# Altium schematic sheet Temp Sensors.SchDoc("Temp Sensors")
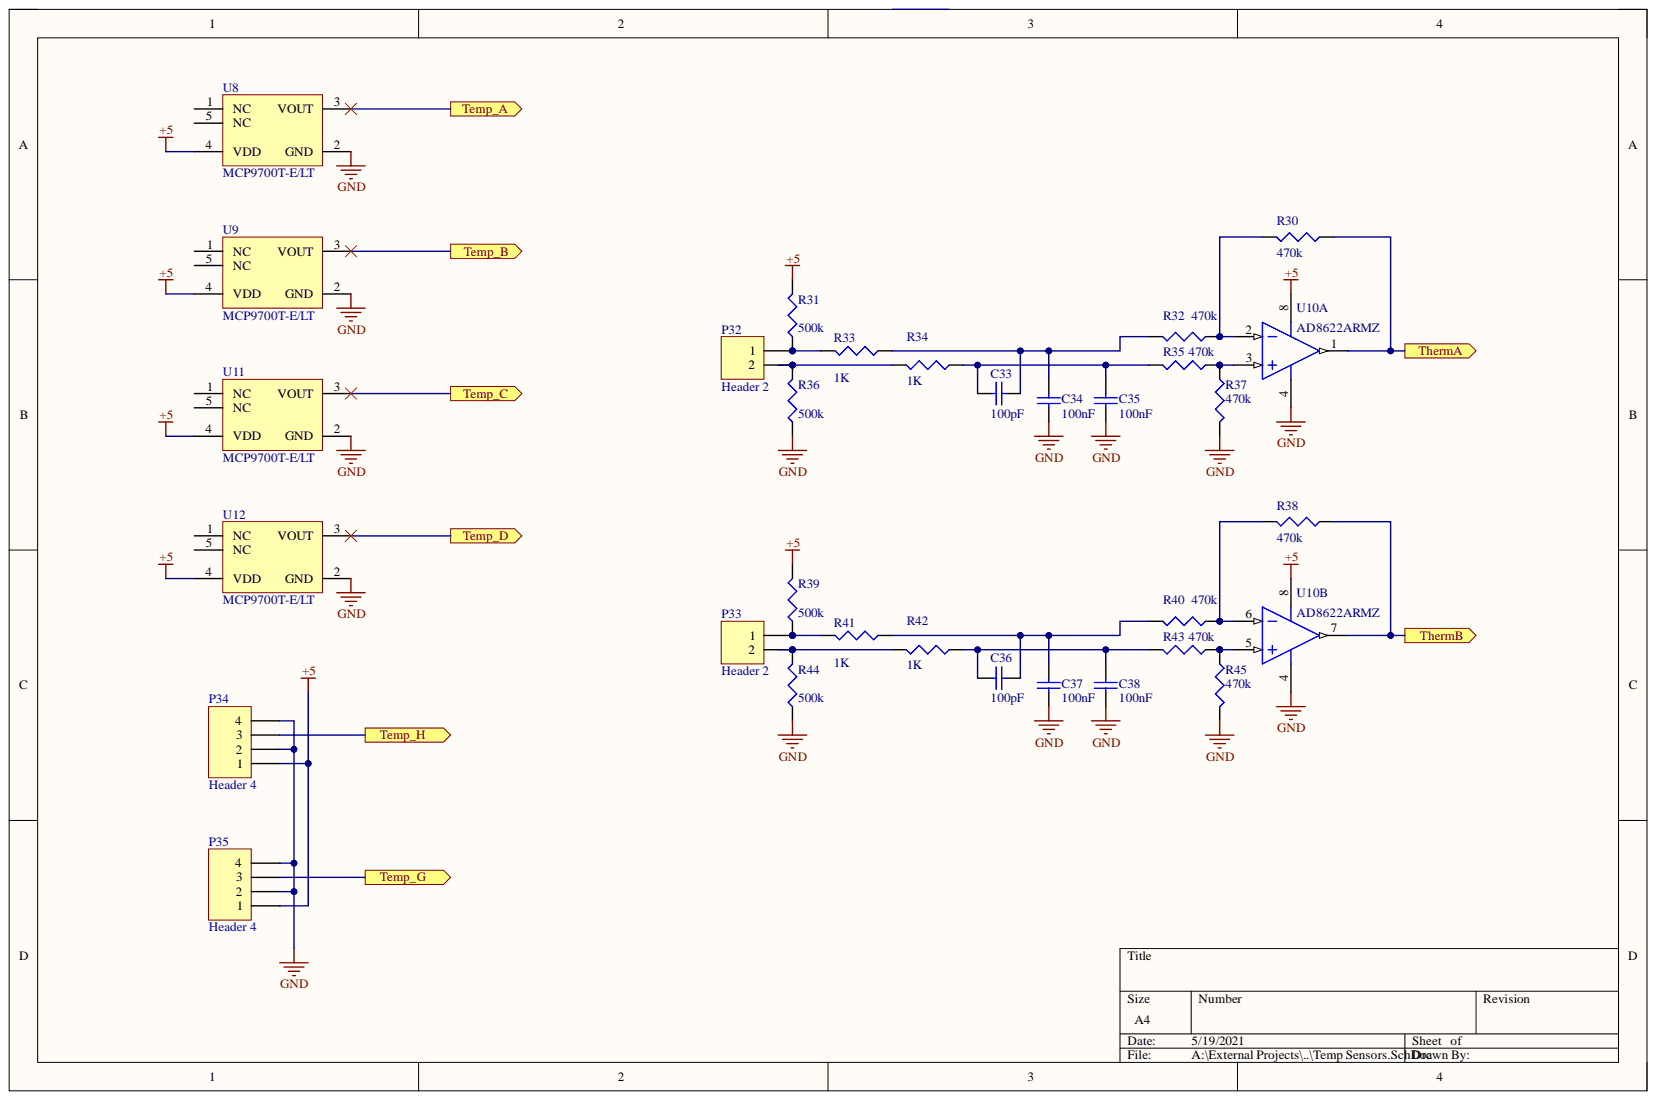
[diagram p0: Temp Sensors.SchDoc]

## Parts
C33  value 100pF  [C1206]  (hidden comment: Cap Semi)
C34  value 100nF  [C1206]  (hidden comment: Cap Semi)
C35  value 100nF  [C1206]  (hidden comment: Cap Semi)
C36  value 100pF  [C1206]  (hidden comment: Cap Semi)
C37  value 100nF  [C1206]  (hidden comment: Cap Semi)
C38  value 100nF  [C1206]  (hidden comment: Cap Semi)
P32  Header 2  [HDR1X2]
P33  Header 2  [HDR1X2]
P34  Header 4  [HDR1X4]
P35  Header 4  [HDR1X4]
R30  value 470k  [J1-0603]  (hidden comment: Res3)
R31  value 500k  [J1-0603]  (hidden comment: Res3)
R32  value 470k  [J1-0603]  (hidden comment: Res3)
R33  value 1K  [J1-0603]  (hidden comment: Res3)
R34  value 1K  [J1-0603]  (hidden comment: Res3)
R35  value 470k  [J1-0603]  (hidden comment: Res3)
R36  value 500k  [J1-0603]  (hidden comment: Res3)
R37  value 470k  [J1-0603]  (hidden comment: Res3)
R38  value 470k  [J1-0603]  (hidden comment: Res3)
R39  value 500k  [J1-0603]  (hidden comment: Res3)
R40  value 470k  [J1-0603]  (hidden comment: Res3)
R41  value 1K  [J1-0603]  (hidden comment: Res3)
R42  value 1K  [J1-0603]  (hidden comment: Res3)
R43  value 470k  [J1-0603]  (hidden comment: Res3)
R44  value 500k  [J1-0603]  (hidden comment: Res3)
R45  value 470k  [J1-0603]  (hidden comment: Res3)
U8  MCP9700T-E/LT  [SC70-LT5_M]
U9  MCP9700T-E/LT  [SC70-LT5_M]
U10  AD8622ARMZ  [ADI-RM-8_L]  (parts A,B)
U11  MCP9700T-E/LT  [SC70-LT5_M]
U12  MCP9700T-E/LT  [SC70-LT5_M]

## Ports
Temp_A, Temp_B, Temp_C, Temp_D, Temp_G, Temp_H, ThermA, ThermB

## Nets
+5: P34.1 P35.1 R31.2 R39.2 U8.4(VDD) U9.4(VDD) U10.8 U11.4(VDD) U12.4(VDD)
GND: C34.1 C35.1 C37.1 C38.1 P34.2 P34.4 P35.2 P35.4 R36.1 R37.2 R44.1 R45.2 U8.2(GND) U9.2(GND) U10.4 U11.2(GND) U12.2(GND)
Temp_A: U8.3(VOUT) ; port Temp_A
Temp_B: U9.3(VOUT) ; port Temp_B
Temp_C: U11.3(VOUT) ; port Temp_C
Temp_D: U12.3(VOUT) ; port Temp_D
Temp_G: P35.3 ; port Temp_G
Temp_H: P34.3 ; port Temp_H
ThermA: R30.1 U10.1 ; port ThermA
ThermB: R38.1 U10.7 ; port ThermB
NetC33_1: C33.1 C35.2 R34.1 R35.2
NetC33_2: C33.2 C34.2 R32.2 R33.1
NetC36_1: C36.1 C38.2 R42.1 R43.2
NetC36_2: C36.2 C37.2 R40.2 R41.1
NetP32_1: P32.1 R31.1 R33.2
NetP32_2: P32.2 R34.2 R36.2
NetP33_1: P33.1 R39.1 R41.2
NetP33_2: P33.2 R42.2 R44.2
NetR30_2: R30.2 R32.1 U10.2
NetR35_1: R35.1 R37.1 U10.3
NetR38_2: R38.2 R40.1 U10.6
NetR43_1: R43.1 R45.1 U10.5

Not connected: U8 1(NC) 5(NC); U9 1(NC) 5(NC); U11 1(NC) 5(NC); U12 1(NC) 5(NC)

## Library data of the parts
C33-C38 = Cap Semi: Capacitor (Semiconductor SIM Model); Component Kind: Standard; LatestRevisionDate: 29-May-2009; LatestRevisionNote: IPC-7351 Footprint Added.; Library Name: Miscellaneous Devices.IntLib; PackageDescription: Chip Capacitor; PackageReference: C1206; Published: 8-Jun-2000; Signal Integrity: Cap Semi; Simulation: CAP; Publisher: Altium Limited
P32 P33 = Header 2: Header, 2-Pin; Component Kind: Standard; LatestRevisionDate: 17-Jul-2002; LatestRevisionNote: Re-released for DXP Platform.; Library Name: Miscellaneous Connectors.IntLib; Signal Integrity: Connector; Publisher: Altium Limited
P34 P35 = Header 4: Header, 4-Pin; Component Kind: Standard; LatestRevisionDate: 17-Jul-2002; LatestRevisionNote: Re-released for DXP Platform.; Library Name: Miscellaneous Connectors.IntLib; Signal Integrity: Connector; Publisher: Altium Limited
R30-R45 = Res3: Resistor; Component Kind: Standard; LatestRevisionDate: 29-May-2009; LatestRevisionNote: IPC-7351 Footprint Added.; Library Name: Miscellaneous Devices.IntLib; PackageDescription: Chip Resistor; PackageReference: J1-0603; Published: 8-Jun-2000; Signal Integrity: Res; Simulation: RESISTOR; Publisher: Altium Limited
U8 U9 U11 U12 = CMP-0192-00174-1: Low-Power Linear Active Thermistor IC, 5-Pin SC-70, Extended Temperature, Tape and Reel; Code_IPC: SOT353-5; Component Kind: Standard; DatasheetVersion: revE, Apr-2009; Library Name: Altium Content Vault; PackageDescription: 5-Lead Plastic Small Outline Transistor (LT) [SC70]; PackageReference: SC70-LT5; PackageVersion: revBB, Aug-2009
U10 = CMP-0384-01734-2: Double Channel Rail-to-Rail Operational Amplifier, 560 KHz BW, 280 mV/us SR, Industrial, 8-pin SOP (RM-8), Tube; Generic Part Number: AD8622; Manufacturer: Analog Devices; Amplifiers Per Package: 2; Input Bias Current: 45pA; Input Offset Voltage: 10uV; Iq/Amp: 215uA; Small Signal Bandwidth: 560KHz; V Span Max: 30V; V Span Min: 5V; Code_JEDEC: MO-187-AA; Component Kind: Standard; ComponentLink1Description: Manufacturer URL; ComponentLink1URL: http://www.analog.com/en/index.html; ComponentLink2Description: Datasheet; ComponentLink2URL: http://www.analog.com/static/imported-files/data_sheets/AD8622_8624.pdf; ComponentLink3Description: Package Specification; ComponentLink3URL: http://www.analog.com/static/imported-files/packages/PKG_PDF/MSOP%28RM%29/RM_8.pdf; ComponentLink4Description: Reference Circuit; ComponentLink4URL: http://www.analog.com/en/all-operational-amplifiers-op-amps/operational-amplifiers-op-amps/ad8622/products/product.html#product-recommendations; DatasheetVersion: Rev. C; Library Name: Altium Content Vault; Mounting Technology: Surface Mount; PackageDescription: 8-Pin Mini Small Outline Package, Body 3 x 3 mm, Pitch 0.65 mm; PackageReference: RM-8; PartNumber: AD8622ARMZ; RevisionNote: Reference Circuit URL fixed; Slew Rate: 280mV/us
